# Altium schematic sheet 05_POWER.SchDoc
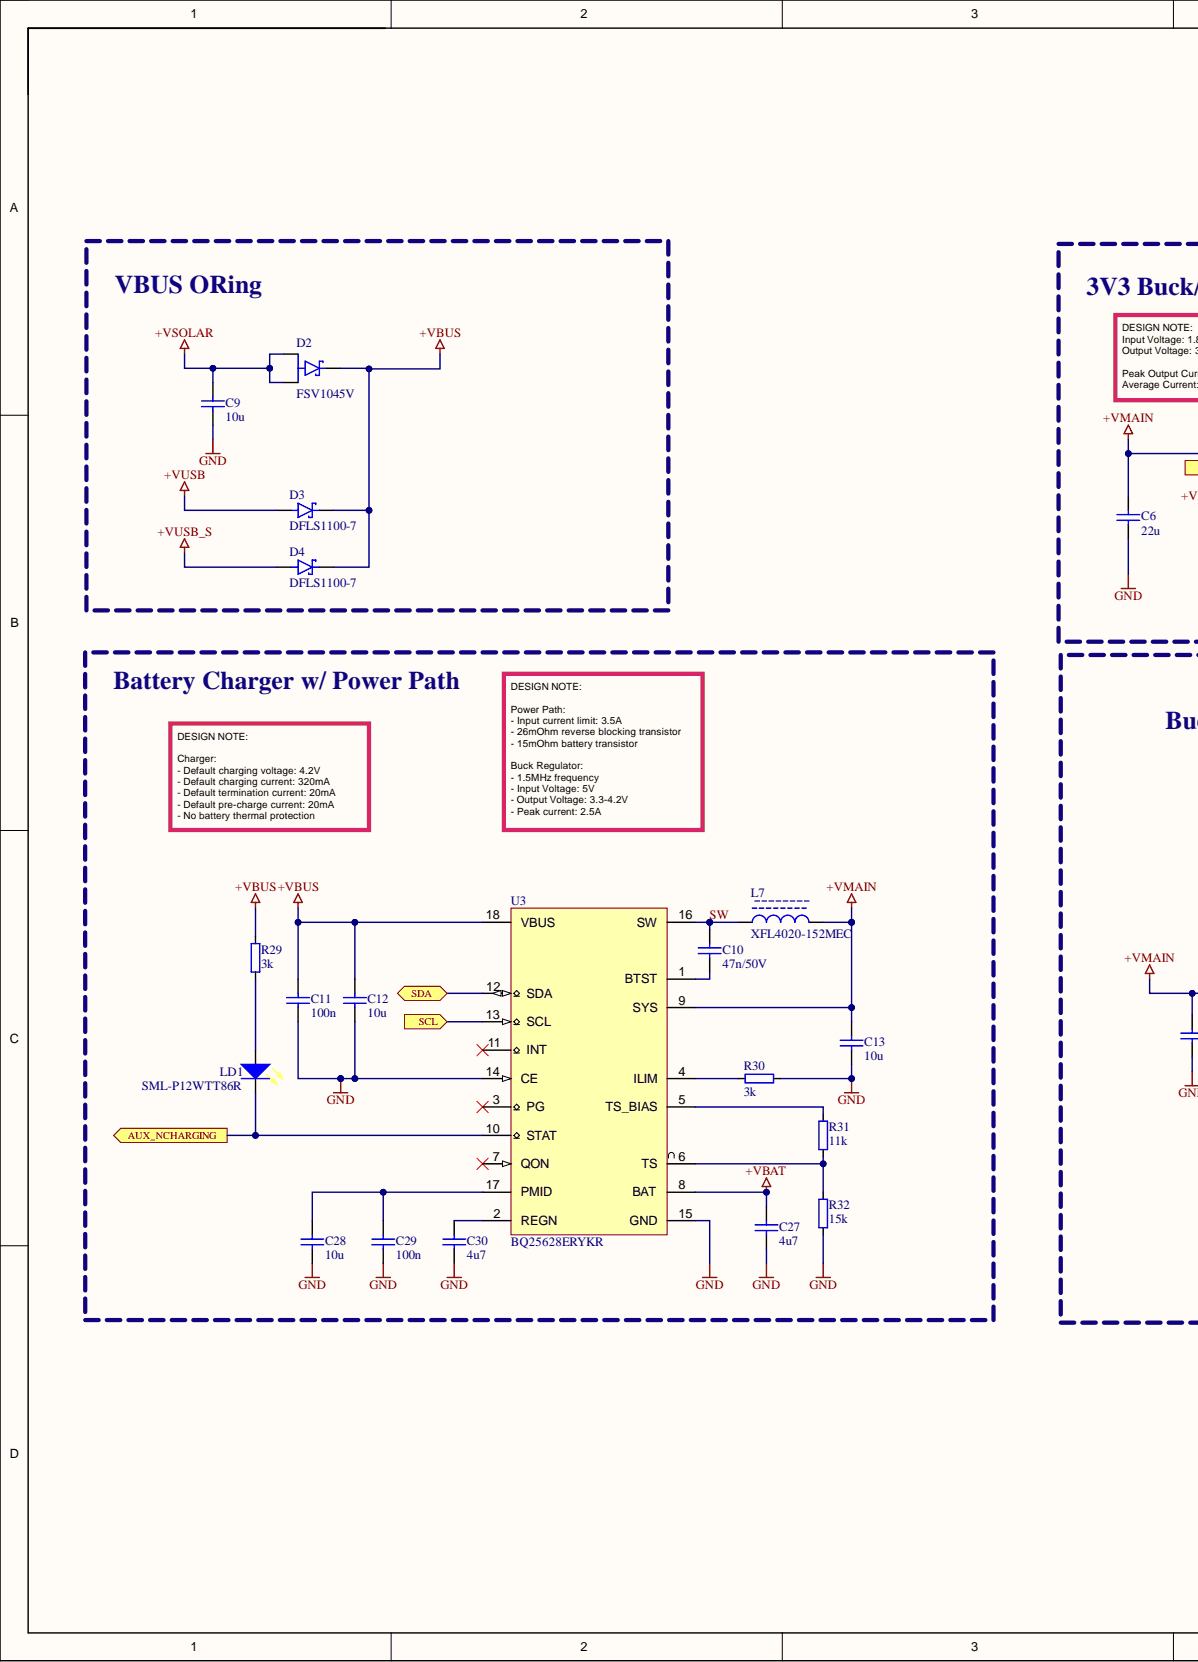
[diagram p0: 05_POWER.SchDoc, left]
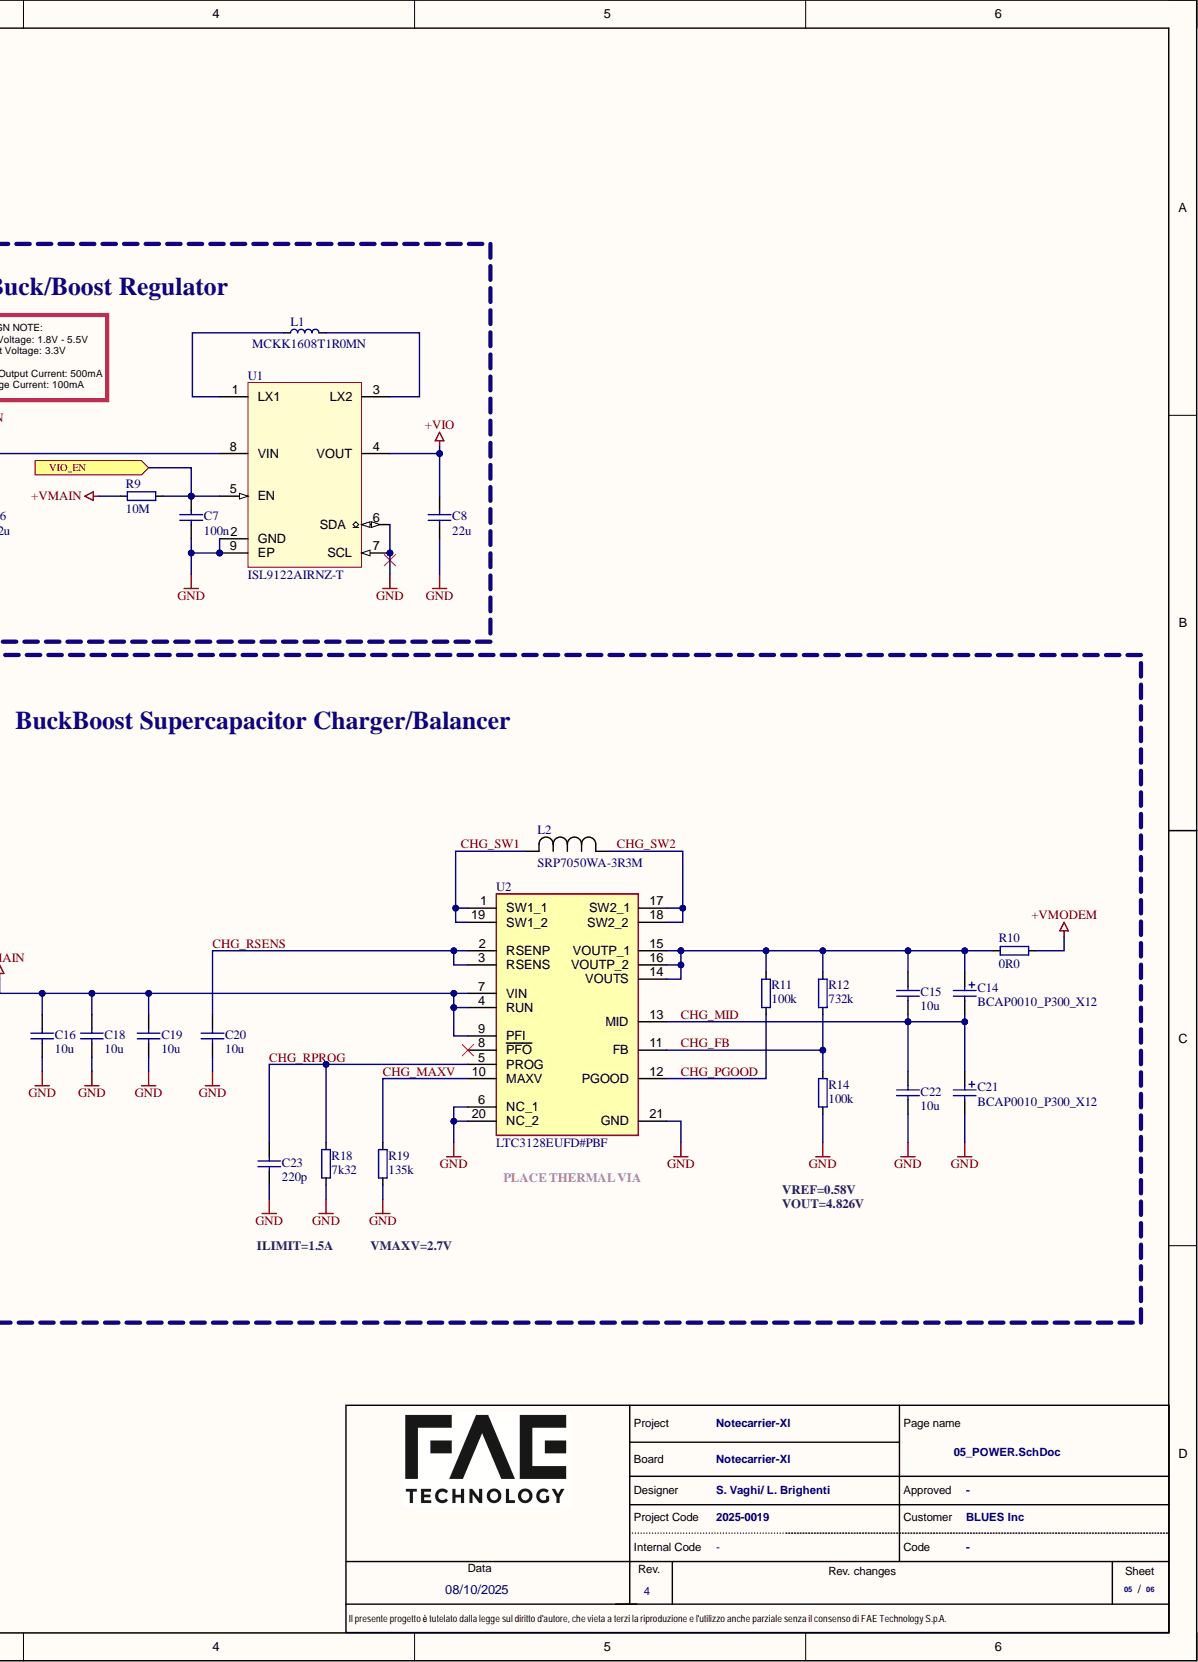
[diagram p1: 05_POWER.SchDoc, right]

## Parts
C6  22u  [C-0805]
C7  100n  [C-0402]
C8  22u  [C-0805]
C9  10u  [C-1206]
C10  47n/50V  [C-0402]
C11  100n  [C-0603]
C12  10u  [C-1206]
C13  10u  [C-1206]
C14  BCAP0010_P300_X12  [BCAP0010P300X12]
C15  10u  [C-1206]
C16  10u  [C-1206]
C18  10u  [C-1206]
C19  10u  [C-1206]
C20  10u  [C-1206]
C21  BCAP0010_P300_X12  [BCAP0010P300X12]
C22  10u  [C-1206]
C23  220p  [C-0402]
C27  4u7  [C-0603]
C28  10u  [C-0805]
C29  100n  [C-0402]
C30  4u7  [C-0603]
D2  FSV1045V  [DIO_FSV1045V]
D3  DFLS1100-7  [DIO_DFLS1100-7]
D4  DFLS1100-7  [DIO_DFLS1100-7]
L1  MCKK1608T1R0MN  [L-0603]
L2  SRP7050WA-3R3M  [IND_SRP7050_BRN]
L7  XFL4020-152MEC  [IND_XFL4020]
LD1  SML-P12WTT86R  [LED-0402]
R9  10M  [R-0402]
R10  0R0  [R-0603]
R11  100k  [R-0402]
R12  732k  [R-0402]
R14  100k  [R-0402]
R18  7k32  [R-0402]
R19  135k  [R-0402]
R29  3k  [R-0402]
R30  3k  [R-0402]
R31  11k  [R-0402]
R32  15k  [R-0402]
U1  ISL9122AIRNZ-T  [DFN50P200X300x75-8T180X165]
U2  LTC3128EUFD#PBF  [QFN50P400X500X80-21N-D]
U3  BQ25628ERYKR  [QFN40P300X150X80-18N]

## Ports
AUX_NCHARGING, SCL, SDA, VIO_EN

## Nets
+VBAT: C27.2 U3.8(BAT)
+VBUS: C11.2 C12.1 D2.3 D3.K D4.K R29.2 U3.18(VBUS)
+VIO: C8.2 U1.4(VOUT)
+VMAIN: C6.2 C13.1 C16.2 C18.2 C19.2 L7.2 R9.1 U1.8(VIN) U2.4(RUN) U2.7(VIN) U2.9(PFI) U3.9(SYS)
+VMODEM: R10.2
+VSOLAR: C9.2 D2.1 D2.2
+VUSB: D3.A
+VUSB_S: D4.A
GND: C6.1 C7.2 C8.1 C9.1 C11.1 C12.2 C13.2 C16.1 C18.1 C19.1 C20.1 C21.2 C22.1 C23.1 C27.1 C28.1 C29.1 C30.1 R14.1 R18.1 R19.1 R30.1 R32.1 U1.2(GND) U1.6(SDA) U1.7(SCL) U1.9(EP) U2.6(NC_1) U2.20(NC_2) U2.21(GND) U3.14(CE) U3.15(GND)
AUX_NCHARGING: LD1.2 U3.10(STAT) ; port AUX_NCHARGING
CHG_FB: R12.1 R14.2 U2.11(FB)
CHG_MAXV: R19.2 U2.10(MAXV)
CHG_MID: C14.2 C15.1 C21.1 C22.2 U2.13(MID)
CHG_PGOOD: R11.2 U2.12(PGOOD)
CHG_RPROG: C23.2 R18.2 U2.5(PROG)
CHG_RSENS: C20.2 U2.2(RSENP) U2.3(RSENS)
CHG_SW1: L2.2 U2.1(SW1_1) U2.19(SW1_2)
CHG_SW2: L2.1 U2.17(SW2_1) U2.18(SW2_2)
SCL: U3.13(SCL) ; port SCL
SDA: U3.12(SDA) ; port SDA
SW: C10.2 L7.1 U3.16(SW)
VIO_EN: C7.1 R9.2 U1.5(EN) ; port VIO_EN
NetC10_1: C10.1 U3.1(BTST)
NetC14_1: C14.1 C15.2 R10.1 R11.1 R12.2 U2.14(VOUTS) U2.15(VOUTP_1) U2.16(VOUTP_2)
NetC28_2: C28.2 C29.2 U3.17(PMID)
NetC30_2: C30.2 U3.2(REGN)
NetL1_1: L1.1 U1.1(LX1)
NetL1_2: L1.2 U1.3(LX2)
NetLD1_1: LD1.1 R29.1
NetR30_2: R30.2 U3.4(ILIM)
NetR31_1: R31.1 U3.5(TS_BIAS)
NetR31_2: R31.2 R32.2 U3.6(TS)

Not connected: U2 8(PFO); U3 3(PG) 7(QON) 11(INT)

## Library data of the parts
C6 C8 = C0805-22u-16V-X5R: Capacitor ceramic 22u ±10% X5R 16V 0805; BL Part Number: 2006-222; Manufacturer: Samsung Electro-Mechanics; Manufacturer Part Number: CL21A226KOQNNNG; Checked_By: Hamin; Drawn_By: Fumic; Pkg Type: SMD; Component Kind: Standard; DateModified: 28-07-2022; Package: 0805; Simulation: CAP; Library Name: 100554_notecarrier-xsi.SCHLIB
C7 = C0402-100n-16V-X7R: Capacitor ceramic 100n ±10% X7R 16V 0402; BL Part Number: 2000-908; Manufacturer: Murata Electronics; Manufacturer Part Number: GRM155R71C104KA88D; Checked_By: Dodig; Drawn_By: Puskar; Pkg Type: SMD; Component Kind: Standard; DateModified: 27-08-2013; Package: 0402; Simulation: CAP; Supplier 1: Digi-Key; Supplier Part Number 1: 490-3261-1-ND; Library Name: 100554_notecarrier-xsi.SCHLIB
C9 C12 = C1206-10u-35V-X7R: Capacitor ceramic 10u ±10% X7R 35V 1206; Manufacturer: Taiyo Yuden; Manufacturer Part Number: GMK316AB7106KL-TR; Pkg Type: SMD; Component Kind: Standard; Package: 1206; Simulation: CAP; Supplier 1: Digi-Key; Library Name: 100554_notecarrier-xsi.SCHLIB
C10 = C0402-47n-50V-X7R: Capacitor ceramic 47n 10% X7R 50V 0402; BL Part Number: 2003-965; Manufacturer: YAGEO; Manufacturer Part Number: CC0402KRX7R9BB473; Checked_By: Hamin; Drawn_By: Hlupic; Pkg Type: SMD; Component Kind: Standard; DateModified: 08-05-2018; Package: 0402; Supplier 1: Digi-Key; Supplier Part Number 1: 311-3815-2-ND; Library Name: 100554_notecarrier-xsi.SCHLIB
C11 = C0603-100n-50V-X7R: Capacitor ceramic 100n ±10% X7R 50V 0603; Manufacturer: KEMET; Manufacturer Part Number: C0603C104K5RACTU; Pkg Type: SMD; Component Kind: Standard; Package: 0603; Library Name: 100554_notecarrier-xsi.SCHLIB
C13 C15 C16 C18-C20 C22 = C1206-10u-16V-X7R: Capacitor ceramic 10u ±10% X7R 16V 1206; BL Part Number: 2000-447; Manufacturer: Samsung Electro-Mechanics; Manufacturer Part Number: CL31B106KOHNNNE; Checked_By: Zvonc; Drawn_By: Dodig; Pkg Type: SMD; Component Kind: Standard; DateModified: 04-07-2013; Package: 1206; Simulation: CAP; Supplier 1: Digi-Key; Supplier Part Number 1: 1276-1137-1-ND; Library Name: 100554_notecarrier-xsi.SCHLIB
C14 C21 = BCAP0010_P300_X12: 10 F  Capacitor 3 V Radial, Can 25mOhm; Manufacturer: Maxwell Technologies; Manufacturer Part Number: BCAP0010 P300 X12; Geometry.Height: 10.5mm; Pkg Type: THT; Component Kind: Standard; Mouser Part Number: 723-BCAP0010P300X12; Mouser Price/Stock: https://www.mouser.co.uk/ProductDetail/Maxwell-Technologies/BCAP0010-P300-X12?qs=hzBznG4dWXUp87%2FIMp%252BJ8w%3D%3D; Library Name: 100554_notecarrier-xsi.SCHLIB
C23 = C0402-220p-50V-C0G-5: Capacitor ceramic 220p ±5% C0G 50V 0402; Manufacturer: Murata Electronics; Manufacturer Part Number: GRM1555C1H221JA01D; Pkg Type: SMD; Component Kind: Standard; Package: 0402; Simulation: CAP; Library Name: 100554_notecarrier-xsi.SCHLIB
C27 C30 = C0603-4u7-10V-X5R: Capacitor ceramic 4u7 ±10% X5R 10V 0603; BL Part Number: 2003-721; Manufacturer: Samsung Electro-Mechanics; Manufacturer Part Number: CL10A475KP8NNNC; Checked_By: Marsic; Drawn_By: Hamin; Pkg Type: SMD; Component Kind: Standard; DateModified: 19-06-2023; Package: 0603; Library Name: 100554_notecarrier-xsi.SCHLIB
C28 = C0805-10u-25V-X5R: Capacitor ceramic 10u ±20% X5R 25V 0805; BL Part Number: 2004-293; Manufacturer: Murata Electronics; Manufacturer Part Number: GRM21BR61E106MA73L; Checked_By: Hamin; Drawn_By: Hlupic; Pkg Type: SMD; Component Kind: Standard; DateModified: 23-01-2019; Package: 0805; Supplier 1: Digi-Key; Supplier Part Number 1: 490-10748-1-ND; Library Name: 100554_notecarrier-xsi.SCHLIB
C29 = C0402-100n-25V-X5R: Capacitor ceramic 100n ±10% X5R 25V 0402; BL Part Number: 2004-296; Manufacturer: Samsung Electro-Mechanics; Manufacturer Part Number: CL05A104KA5NNNC; Checked_By: Hamin; Drawn_By: PuskarJ; Pkg Type: SMD; Component Kind: Standard; DateModified: 24-01-2019; Package: 0402; Simulation: CAP; Supplier 1: Digi-Key; Supplier Part Number 1: 1276-1043-1-ND; Library Name: 100554_notecarrier-xsi.SCHLIB
D2 = FSV1045V: Diode Schottky 10A 45V TO2773 | onsemi FSV1045V; Pkg Type: SMD; Component Kind: Standard; Manufacturer: onsemi; Manufacturer Part Number: FSV1045V; Package: TO-2773; Library Name: 100554_notecarrier-xsi.SCHLIB
D3 D4 = DFLS1100-7: Diode Schottky 1A 100V PowerDI 123 | Diodes Inc DFLS1100-7; Manufacturer: Diodes Inc.; Pkg Type: SMD; Component Kind: Standard; Manufacturer Part Number: DFLS1100-7; Package: POWERDI123; Library Name: 100554_notecarrier-xsi.SCHLIB
L1 = L0603-1u-20 MCKK1608T1R0MN: Inductor 0603 1u ±20% 1.3A; BL Part Number: 2003-989; Manufacturer: Taiyo Yuden; Checked_By: Hlupic; Drawn_By: Fumic; Component Kind: Standard; DateModified: 30-01-2020; Library Name: BL_Passive.SchLib; Manufacturer Part Number: MCKK1608T1R0MN; Supplier 1: Digi-Key; Supplier Part Number 1: 587-5973-1-ND
L2 = SRP7050WA-3R3M: 3.3 µH Inductor Shielded 11.5 A 15mohm max; Manufacturer: Bourns Electronics; Pkg Type: SMD; Type: Fixed Inductors; Component Kind: Standard; Manufacturer Part Number: SRP7050WA-3R3M; Package: 7.90mm x 7.30mm; Library Name: 100554_notecarrier-xsi.SCHLIB
L7 = Inductor XFL4020-152MEC: Inductor 1u5 ±20% 4.6A SMD; BL Part Number: 2006-846; Manufacturer: Coilcraft; Checked_By: Hlupic; Drawn_By: Marsic; Component Kind: Standard; DateModified: 29-09-2022; Manufacturer Part Number: XFL4020-152MEC; Pkg Type: SMD; Library Name: 100554_notecarrier-xsi.SCHLIB
LD1 = LED_SML-P12WTT86R: LED YELLOW CLEAR 0402 SMD; BL Part Number: 2007-660; Manufacturer: ROHM Semiconductor; Checked_By: Hamin; Component Kind: Standard; DateModified: 19-06-23; Manufacturer Part Number: SML-P12WTT86R; Pkg Type: SMD; Drawn_By: Hamin; Library Name: 100554_notecarrier-xsi.SCHLIB
R9 = R0402-10M-1: Resistor 10M ±1% 0402; BL Part Number: 2002-832; Manufacturer: YAGEO; Manufacturer Part Number: RC0402FR-0710ML; Checked_By: Dodig; Drawn_By: Zvonc; Pkg Type: SMD; Component Kind: Standard; DateModified: 24-05-2016; Package: 0402; Supplier 1: Digi-Key; Supplier Part Number 1: RC0402FR-0710ML; Library Name: 100554_notecarrier-xsi.SCHLIB
R10 = R0603-0R0: Resistor 0R0 0603; BL Part Number: 2000-723; Manufacturer: YAGEO; Manufacturer Part Number: RC0603JR-070RL; Checked_By: Puskar; Drawn_By: Dodig; Pkg Type: SMD; Component Kind: Standard; DateModified: 07-12-2013; Package: 0603; Library Name: 100554_notecarrier-xsi.SCHLIB
R11 R14 = R0402-100k-1: Resistor 100k ±1% 0402; Manufacturer: YAGEO; Manufacturer Part Number: RC0402FR-07100KL; Pkg Type: SMD; Component Kind: Standard; Package: 0402; Library Name: 100554_notecarrier-xsi.SCHLIB
R12 = R0402-732k-1: Resistor 732k ±1% 0402; Manufacturer: YAGEO; Manufacturer Part Number: RC0402FR-07732KL; Pkg Type: SMD; Component Kind: Standard; Package: 0402; Library Name: 100554_notecarrier-xsi.SCHLIB
R18 = R0402-7k32-1: Resistor 7k32 ±1% 0402; Manufacturer: YAGEO; Manufacturer Part Number: RC0402FR-077K32L; Pkg Type: SMD; Component Kind: Standard; Package: 0402; Supplier 1: Digi-Key; Library Name: 100554_notecarrier-xsi.SCHLIB
R19 = R0402-135k-1: Resistor 135k ±1% 0402; Manufacturer: KOA Speer Electronics, Inc.; Manufacturer Part Number: RN73H1ETTP1353F50; Pkg Type: SMD; Component Kind: Standard; Package: 0402; Supplier 1: Digi-Key; Library Name: 100554_notecarrier-xsi.SCHLIB
R29 R30 = R0402-3k-1: Resistor 3k ±1% 0402; BL Part Number: 2001-570; Manufacturer: YAGEO; Checked_By: Hamin; Drawn_By: Fumic; Pkg Type: SMD; Component Kind: Standard; DateModified: 06-09-2021; Manufacturer Part Number: -; Package: 0402; Library Name: 100554_notecarrier-xsi.SCHLIB
R31 = R0402-11k-1: Resistor 11k ±1% 0402; BL Part Number: 2004-237; Manufacturer: YAGEO; Manufacturer Part Number: RC0402FR-0711KP; Checked_By: Marsic; Drawn_By: PuskarJ; Pkg Type: SMD; Component Kind: Standard; DateModified: 18-12-2018; Package: 0402; Library Name: 100554_notecarrier-xsi.SCHLIB
R32 = R0402-15k-1: Resistor 15k ±1% 0402; BL Part Number: 2001-157; Manufacturer: Panasonic Electronic Components; Manufacturer Part Number: ERJ-2RKF1502X; Checked_By: Zvonc; Drawn_By: Puskar; Pkg Type: SMD; Component Kind: Standard; DateModified: 23-01-2015; Package: 0402; Supplier 1: Digi-Key; Supplier Part Number 1: P15.0KLCT-ND; Library Name: 100554_notecarrier-xsi.SCHLIB
U1 = ISL9122AIRNZ-T: IC CON BUCK-BOOST ADJ 0.5A DFN-8; BL Part Number: 2008-968; Manufacturer: Renesas; Drawn_By: Marsic; Component Kind: Standard; DateModified: 19-11-2024; Library Name: BL_Analog.SchLib; Manufacturer Part Number: ISL9122AIRNZ-T
U2 = LTC3128EUFD#PBF: IC REG BuckBoost Supercapacitor Charger and Balancer ADJ 3A 20QFN; Manufacturer: Analog Devices; Geometry.Height: 0.8mm; Pkg Type: SMD; Component Kind: Standard; Datasheet Link: https://www.analog.com/en/products/ltc3128.html; Manufacturer Part Number: LTC3128EUFD#PBF; Package: QFN20; Library Name: 100554_notecarrier-xsi.SCHLIB
U3 = BQ25628ERYKR: IC LI-ION CHARGE MGMT 16-QFN; BL Part Number: 2008-206; Manufacturer: Texas Instruments; Drawn_By: Marsic; Pkg Type: SMD; Component Kind: Standard; DateModified: 17-01-2024; Manufacturer Part Number: BQ25628ERYKR; Supplier 1: Digi-Key; Supplier Part Number 1: 296-BQ25628ERYKRCT-ND - Cut Tape (CT); Library Name: 100554_notecarrier-xsi.SCHLIB
